# Altium schematic sheet LoraCPu.SchDoc
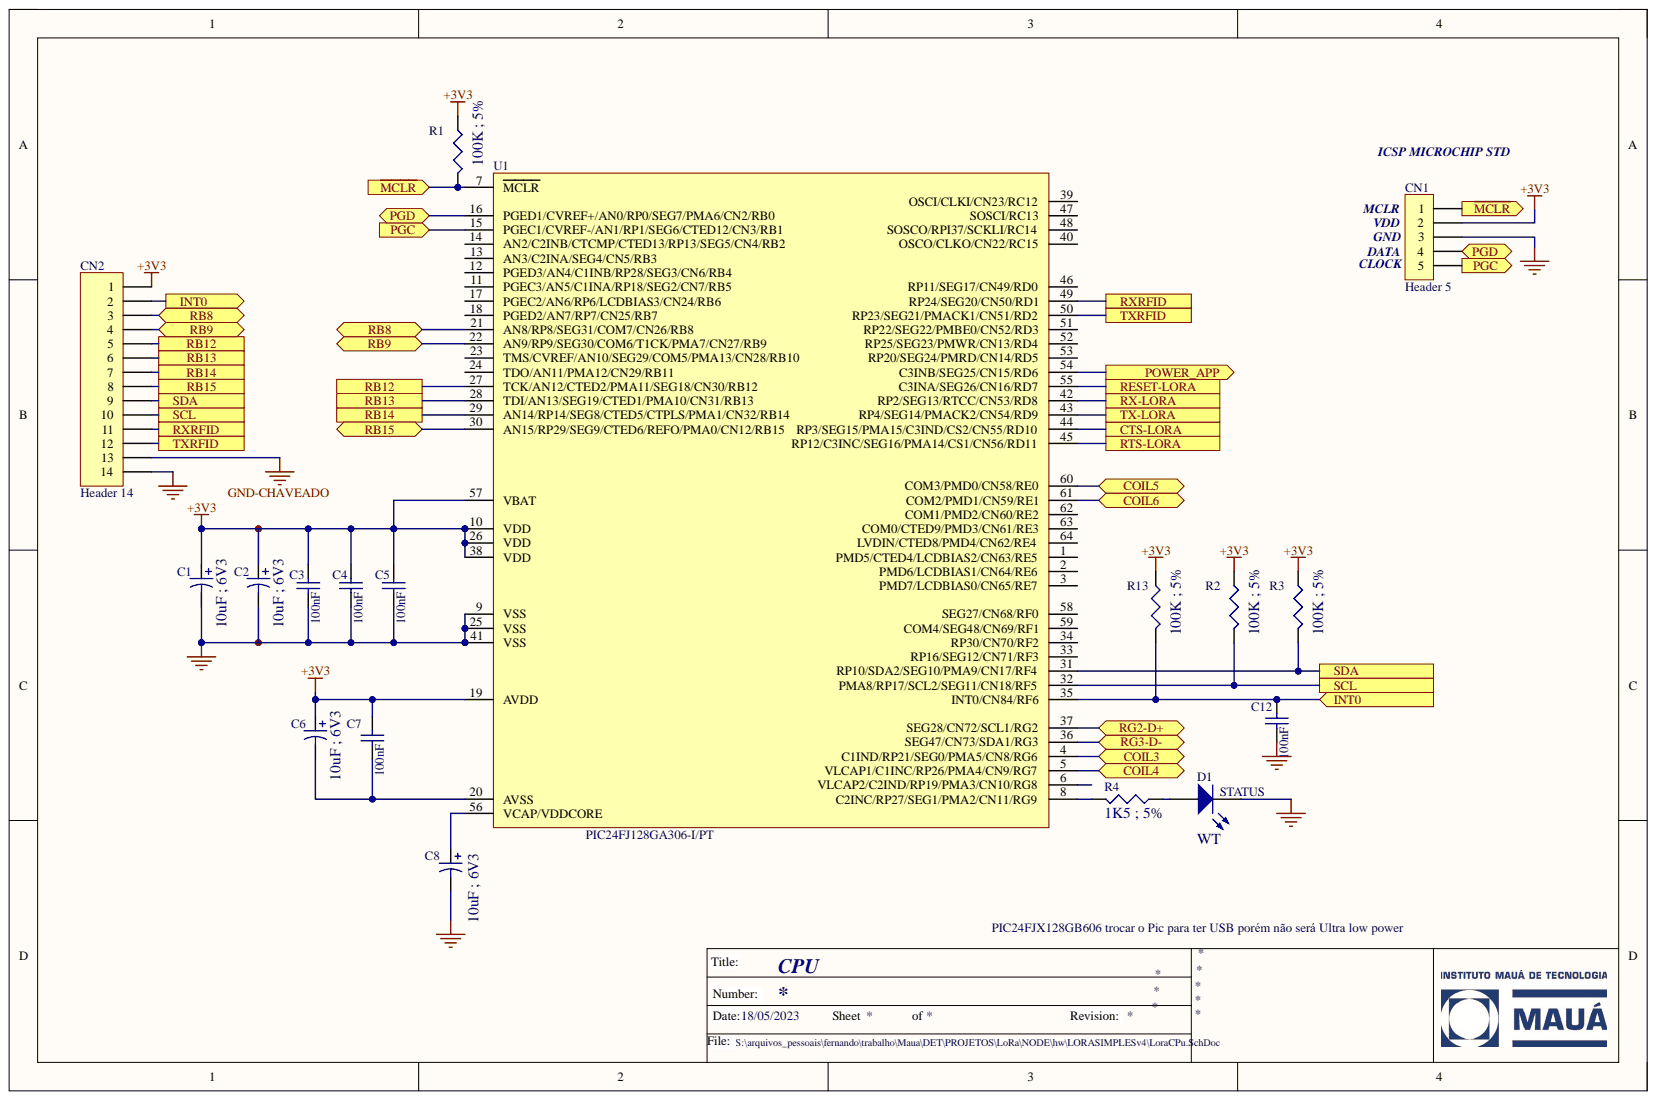
[diagram p0: LoraCPu.SchDoc]

## Parts
C1  Valor: 10uF ; 6V3  [CASE-A]  (hidden comment: 02.0103)
C2  Valor: 10uF ; 6V3  [CASE-A]  (hidden comment: 02.0103)
C3  Valor: 100nF  [0603 - CAP]  (hidden comment: Capacitor Cerâmico SMD)
C4  Valor: 100nF  [0603 - CAP]  (hidden comment: Capacitor Cerâmico SMD)
C5  Valor: 100nF  [0603 - CAP]  (hidden comment: Capacitor Cerâmico SMD)
C6  Valor: 10uF ; 6V3  [CASE-A]  (hidden comment: 02.0103)
C7  Valor: 100nF  [0603 - CAP]  (hidden comment: Capacitor Cerâmico SMD)
C8  Valor: 10uF ; 6V3  [CASE-A]  (hidden comment: 02.0103)
C12  Valor: 100nF  [0603 - CAP]  (hidden comment: Capacitor Cerâmico SMD)
CN1  Header 5  [HDR1X5]
CN2  Header 14  [HDR1X14]
D1  Valor: WT  [LED 0805 AM]  (hidden comment: 09.0032)
R1  Valor: 100K ; 5%  [0603]
R2  Valor: 100K ; 5%  [0603]
R3  Valor: 100K ; 5%  [0603]
R4  Valor: 1K5 ; 5%  [0603]
R13  Valor: 100K ; 5%  [0603]
U1  (no value)  [TQFP-64_MICROCHIP]

## Ports
COIL3, COIL4, COIL5, COIL6, CTS-LORA, INT0, PGC, PGD, POWER_APP, RB8, RB9, RB12, RB13, RB14, RB15, RESET-LORA, RG2-D+, RG3-D-, RTS-LORA, RX-LORA, RXRFID, SCL, SDA, TX-LORA, TXRFID, ~{MCLR}

## Nets
+3V3: C1.1 C2.1 C3.2 C4.2 C5.2 C6.1 C7.2 CN1.2 CN2.1 R1.1 R2.1 R3.1 R13.1 U1.10(VDD) U1.19(AVDD) U1.26(VDD) U1.38(VDD) U1.57(VBAT)
GND: C1.2 C2.2 C3.1 C4.1 C5.1 C8.2 C12.1 CN1.3 CN2.14 D1.2 U1.9(VSS) U1.25(VSS) U1.41(VSS)
GND-CHAVEADO: CN2.13
COIL3: U1.4(C1IND/RP21/SEG0/PMA5/CN8/RG6) ; port COIL3
COIL4: U1.5(VLCAP1/C1INC/RP26/PMA4/CN9/RG7) ; port COIL4
COIL5: U1.60(COM3/PMD0/CN58/RE0) ; port COIL5
COIL6: U1.61(COM2/PMD1/CN59/RE1) ; port COIL6
CTS-LORA: U1.44(RP3/SEG15/PMA15/C3IND/CS2/CN55/RD10) ; port CTS-LORA
INT0: C12.2 CN2.2 R13.2 U1.35(INT0/CN84/RF6) ; port INT0
~{MCLR}: CN1.1 R1.2 U1.7(MCLR) ; port ~{MCLR}
PGC: CN1.5 U1.15(PGEC1/CVREF-/AN1/RP1/SEG6/CTED12/CN3/RB1) ; port PGC
PGD: CN1.4 U1.16(PGED1/CVREF+/AN0/RP0/SEG7/PMA6/CN2/RB0) ; port PGD
POWER_APP: U1.54(C3INB/SEG25/CN15/RD6) ; port POWER_APP
RB8: CN2.3 U1.21(AN8/RP8/SEG31/COM7/CN26/RB8) ; port RB8
RB9: CN2.4 U1.22(AN9/RP9/SEG30/COM6/T1CK/PMA7/CN27/RB9) ; port RB9
RB12: CN2.5 U1.27(TCK/AN12/CTED2/PMA11/SEG18/CN30/RB12) ; port RB12
RB13: CN2.6 U1.28(TDI/AN13/SEG19/CTED1/PMA10/CN31/RB13) ; port RB13
RB14: CN2.7 U1.29(AN14/RP14/SEG8/CTED5/CTPLS/PMA1/CN32/RB14) ; port RB14
RB15: CN2.8 U1.30(AN15/RP29/SEG9/CTED6/REFO/PMA0/CN12/RB15) ; port RB15
RESET-LORA: U1.55(C3INA/SEG26/CN16/RD7) ; port RESET-LORA
RG2-D+: U1.37(SEG28/CN72/SCL1/RG2) ; port RG2-D+
RG3-D-: U1.36(SEG47/CN73/SDA1/RG3) ; port RG3-D-
RTS-LORA: U1.45(RP12/C3INC/SEG16/PMA14/CS1/CN56/RD11) ; port RTS-LORA
RX-LORA: U1.42(RP2/SEG13/RTCC/CN53/RD8) ; port RX-LORA
RXRFID: CN2.11 U1.49(RP24/SEG20/CN50/RD1) ; port RXRFID
SCL: CN2.10 R2.2 U1.32(PMA8/RP17/SCL2/SEG11/CN18/RF5) ; port SCL
SDA: CN2.9 R3.2 U1.31(RP10/SDA2/SEG10/PMA9/CN17/RF4) ; port SDA
TX-LORA: U1.43(RP4/SEG14/PMACK2/CN54/RD9) ; port TX-LORA
TXRFID: CN2.12 U1.50(RP23/SEG21/PMACK1/CN51/RD2) ; port TXRFID
NetC6_2: C6.2 C7.1 U1.20(AVSS)
NetC8_1: C8.1 U1.56(VCAP/VDDCORE)
NetD1_1: D1.1 R4.1
NetR4_2: R4.2 U1.8(C2INC/RP27/SEG1/PMA2/CN11/RG9)

Not connected: U1 1(PMD5/CTED4/LCDBIAS2/CN63/RE5) 2(PMD6/LCDBIAS1/CN64/RE6) 3(PMD7/LCDBIAS0/CN65/RE7) 6(VLCAP2/C2IND/RP19/PMA3/CN10/RG8) 11(PGEC3/AN5/C1INA/RP18/SEG2/CN7/RB5) 12(PGED3/AN4/C1INB/RP28/SEG3/CN6/RB4) 13(AN3/C2INA/SEG4/CN5/RB3) 14(AN2/C2INB/CTCMP/CTED13/RP13/SEG5/CN4/RB2) 17(PGEC2/AN6/RP6/LCDBIAS3/CN24/RB6) 18(PGED2/AN7/RP7/CN25/RB7) 23(TMS/CVREF/AN10/SEG29/COM5/PMA13/CN28/RB10) 24(TDO/AN11/PMA12/CN29/RB11) 33(RP16/SEG12/CN71/RF3) 34(RP30/CN70/RF2) 39(OSCI/CLKI/CN23/RC12) 40(OSCO/CLKO/CN22/RC15) 46(RP11/SEG17/CN49/RD0) 47(SOSCI/RC13) 48(SOSCO/RPI37/SCKLI/RC14) 51(RP22/SEG22/PMBE0/CN52/RD3) 52(RP25/SEG23/PMWR/CN13/RD4) 53(RP20/SEG24/PMRD/CN14/RD5) 58(SEG27/CN68/RF0) 59(COM4/SEG48/CN69/RF1) 62(COM1/PMD2/CN60/RE2) 63(COM0/CTED9/PMD3/CN61/RE3) 64(LVDIN/CTED8/PMD4/CN62/RE4)

## Library data of the parts
C1 C2 C6 C8 = Capacitor de tântalo: Grupo: 02; Component Kind: Standard; Description: Capacitor de tântalo; Library Name: Miscellaneous Devices.IntLib
C3-C5 C7 C12 = Capacitor Cerâmico SMD: Encapsulamento: 0402; Component Kind: Standard; Description: Capacitor Cerâmico SMD; Library Name: Miscellaneous Devices.IntLib
CN1 = Header 5: Header, 5-Pin; Component Kind: Standard; LatestRevisionDate: 17-Jul-2002; LatestRevisionNote: Re-released for DXP Platform.; Library Name: Miscellaneous Connectors.IntLib; Signal Integrity: Connector; Publisher: Altium Limited
CN2 = Header 14: Header, 14-Pin; Component Kind: Standard; LatestRevisionDate: 17-Jul-2002; LatestRevisionNote: Re-released for DXP Platform.; Library Name: Miscellaneous Connectors.IntLib; Signal Integrity: Connector; Publisher: Altium Limited
D1 = LED2: Led; Encapsulamento: 0805; Grupo: 09; Component Kind: Standard; Library Name: Miscellaneous Devices.IntLib; Simulation: LED2
R1-R4 R13 = Res3: Resistor; Encapsulamento: 0402; Grupo: 01; Component Kind: Standard; LatestRevisionDate: 04-Mar-2005; LatestRevisionNote: PCB Footprint replaced.; Library Name: Miscellaneous Devices.IntLib; PackageReference: 0402; Published: 8-Jun-2000; Signal Integrity: Res; Simulation: RESISTOR; Publisher: Altium Limited
U1 = PIC24FJ128GA306-I/PT: Microcontrolador PIC; Encapsulamento: TQFP-64; Fabricante: MICROCHIP; Fornecedor: INTERTEK; Grupo: 07; Component Kind: Standard; Library Name: Microchip Microcontroller PIC24.IntLib; Part number: PIC24FJ128GA306-I/PT; Valor: PIC24FJ128GA306-I/PT
